# Altium schematic sheet Measurement.SchDoc(Measurement)
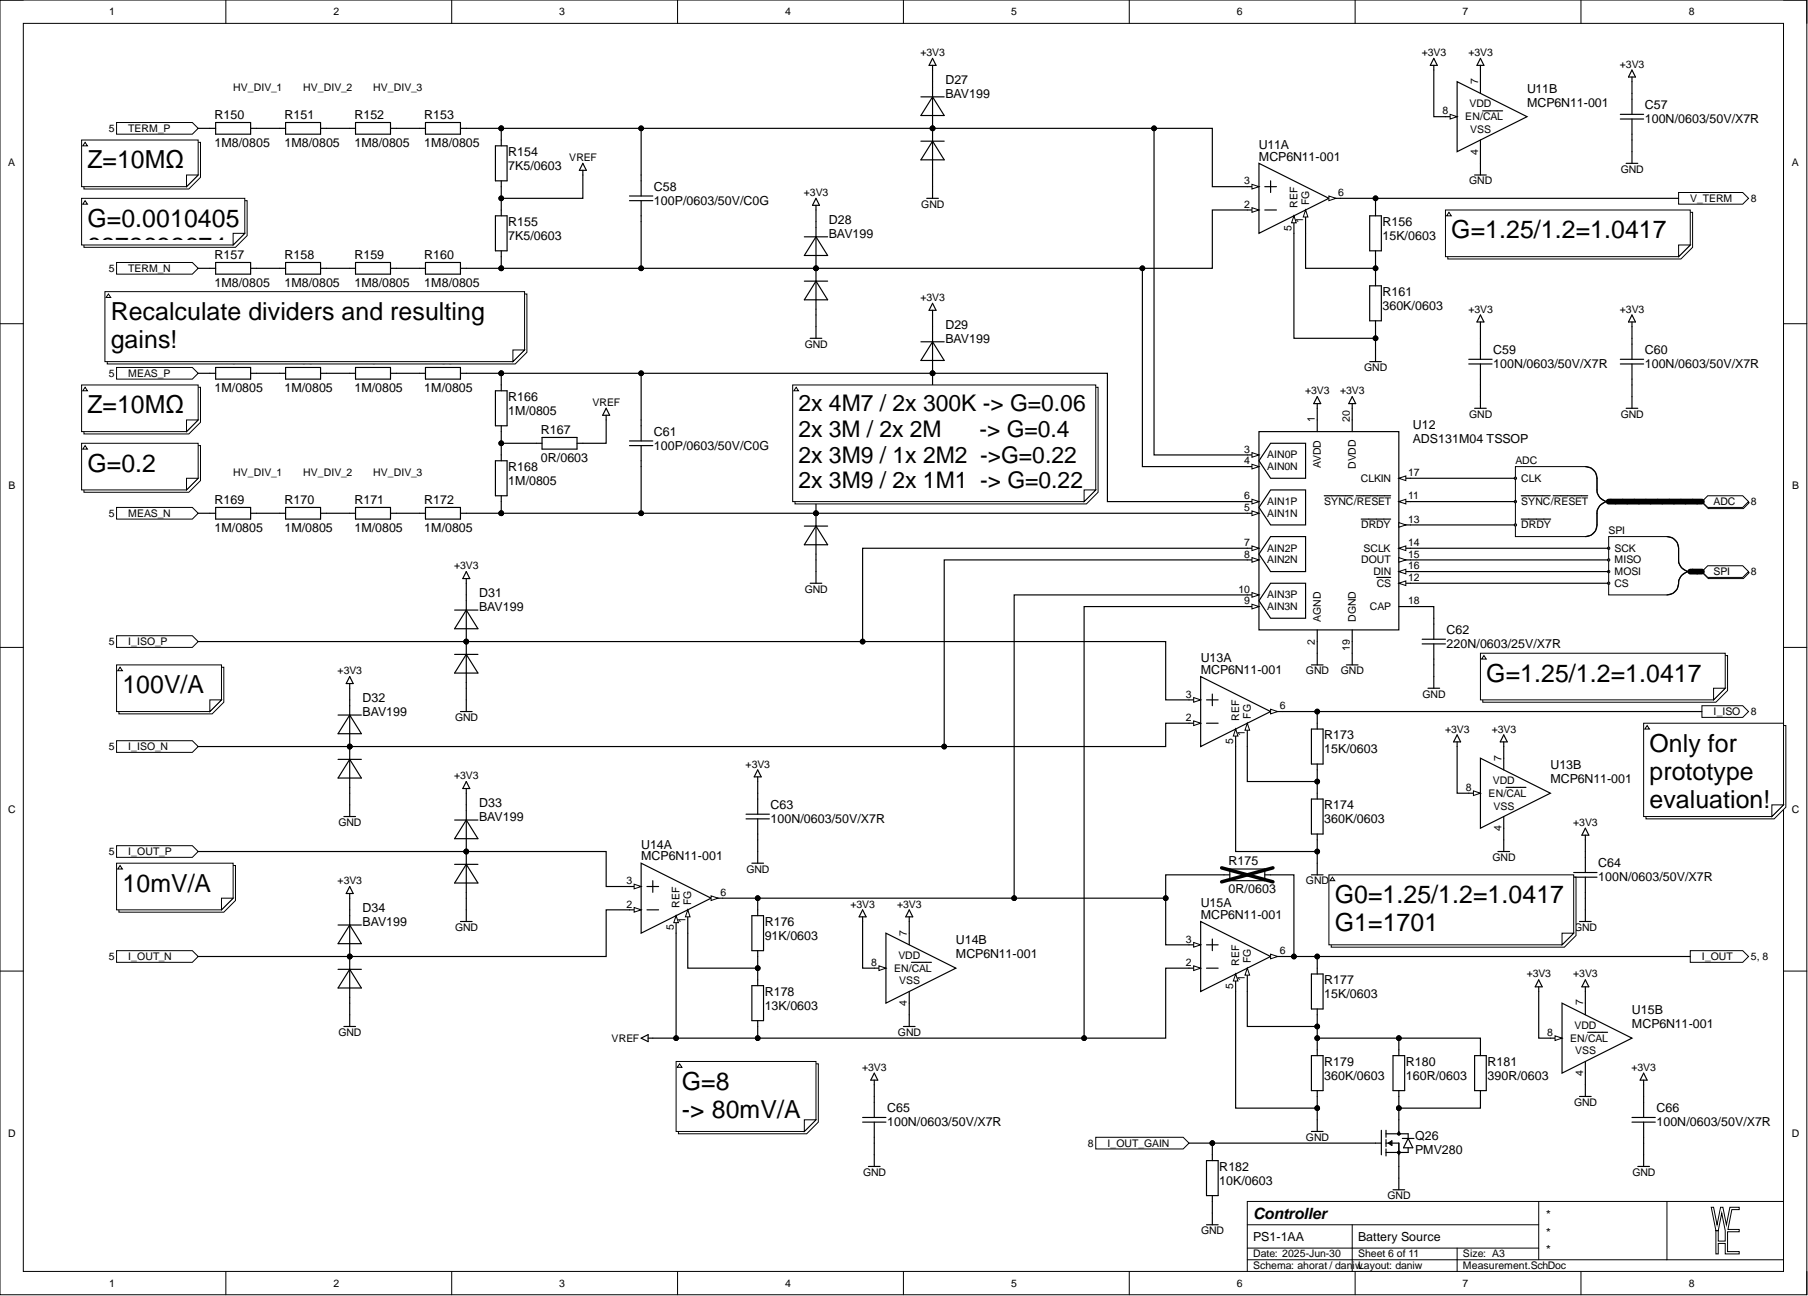
[diagram p0: Measurement.SchDoc]

## Parts
C57  100N/0603/50V/X7R  [CAP0603_X7R]
C58  100P/0603/50V/C0G  [CAP0603_C0G]
C59  100N/0603/50V/X7R  [CAP0603_X7R]
C60  100N/0603/50V/X7R  [CAP0603_X7R]
C61  100P/0603/50V/C0G  [CAP0603_C0G]
C62  220N/0603/25V/X7R  [CAP0603_X7R]
C63  100N/0603/50V/X7R  [CAP0603_X7R]
C64  100N/0603/50V/X7R  [CAP0603_X7R]
C65  100N/0603/50V/X7R  [CAP0603_X7R]
C66  100N/0603/50V/X7R  [CAP0603_X7R]
D27  BAV199  [SOT2395P280X125-3-SE]
D28  BAV199  [SOT2395P280X125-3-SE]
D29  BAV199  [SOT2395P280X125-3-SE]
D30  BAV199  [SOT2395P280X125-3-SE]
D31  BAV199  [SOT2395P280X125-3-SE]
D32  BAV199  [SOT2395P280X125-3-SE]
D33  BAV199  [SOT2395P280X125-3-SE]
D34  BAV199  [SOT2395P280X125-3-SE]
Q26  PMV280  [SOT2395P280X125-3-SE]
R150  1M8/0805  [R0805-0.50-WW]
R151  1M8/0805  [R0805-0.50-WW]
R152  1M8/0805  [R0805-0.50-WW]
R153  1M8/0805  [R0805-0.50-WW]
R154  7K5/0603  [R0603-0.40-WW]
R155  7K5/0603  [R0603-0.40-WW]
R156  15K/0603  [R0603-0.40-WW]
R157  1M8/0805  [R0805-0.50-WW]
R158  1M8/0805  [R0805-0.50-WW]
R159  1M8/0805  [R0805-0.50-WW]
R160  1M8/0805  [R0805-0.50-WW]
R161  360K/0603  [R0603-0.40-WW]
R162  1M/0805  [R0805-0.50-WW]
R163  1M/0805  [R0805-0.50-WW]
R164  1M/0805  [R0805-0.50-WW]
R165  1M/0805  [R0805-0.50-WW]
R166  1M/0805  [R0805-0.50-WW]
R167  0R/0603  [R0603-0.40-WW]
R168  1M/0805  [R0805-0.50-WW]
R169  1M/0805  [R0805-0.50-WW]
R170  1M/0805  [R0805-0.50-WW]
R171  1M/0805  [R0805-0.50-WW]
R172  1M/0805  [R0805-0.50-WW]
R173  15K/0603  [R0603-0.40-WW]
R174  360K/0603  [R0603-0.40-WW]
R175  0R/0603  [R0603-0.40-WW]
R176  91K/0603  [R0603-0.40-WW]
R177  15K/0603  [R0603-0.40-WW]
R178  13K/0603  [R0603-0.40-WW]
R179  360K/0603  [R0603-0.40-WW]
R180  160R/0603  [R0603-0.40-WW]
R181  390R/0603  [R0603-0.40-WW]
R182  10K/0603  [R0603-0.40-WW]
U11  MCP6N11-001  [SOP127P600X155-8-SW]  (parts A,B)
U12  ADS131M04 TSSOP  [SOP065P640X120-20NW]
U13  MCP6N11-001  [SOP127P600X155-8-SW]  (parts A,B)
U14  MCP6N11-001  [SOP127P600X155-8-SW]  (parts A,B)
U15  MCP6N11-001  [SOP127P600X155-8-SW]  (parts A,B)

## Ports
ADC, ADC.CLK, ADC.~{DRDY}, ADC.~{SYNC}/~{RESET}, I_ISO, I_ISO_N, I_ISO_P, I_OUT, I_OUT_GAIN, I_OUT_N, I_OUT_P, MEAS_N, MEAS_P, SPI, SPI.CS, SPI.MISO, SPI.MOSI, SPI.SCK, TERM_N, TERM_P, V_TERM

## Nets
+3V3: C57.1 C59.1 C60.1 C63.1 C64.1 C65.1 C66.1 D27.2 D28.2 D29.2 D30.2 D31.2 D32.2 D33.2 D34.2 U11.7 U11.8(EN/CAL) U12.1(AVDD) U12.20(DVDD) U13.7 U13.8(EN/CAL) U14.7 U14.8(EN/CAL) U15.7 U15.8(EN/CAL)
GND: C57.2 C59.2 C60.2 C62.2 C63.2 C64.2 C65.2 C66.2 D27.1 D28.1 D29.1 D30.1 D31.1 D32.1 D33.1 D34.1 Q26.2 R161.2 R174.2 R179.2 R182.2 U11.4 U11.5(REF) U12.2(AGND) U12.19(DGND) U13.4 U13.5(REF) U14.4 U15.4 U15.5(REF)
VREF: R154.2 R155.1 R167.2 R178.2 U12.9(AIN3N) U14.5(REF) U15.2
I_ISO: R173.1 U13.6 ; port I_ISO
I_ISO_N: D32.3 U12.8(AIN2N) U13.2 ; port I_ISO_N
I_ISO_P: D31.3 U12.7(AIN2P) U13.3 ; port I_ISO_P
I_OUT: R175.2 R177.1 U15.6 ; port I_OUT
I_OUT_GAIN: Q26.1 R182.1 ; port I_OUT_GAIN
I_OUT_N: D34.3 U14.2 ; port I_OUT_N
I_OUT_P: D33.3 U14.3 ; port I_OUT_P
MEAS_N: R169.1 ; port MEAS_N
MEAS_P: R162.1 ; port MEAS_P
TERM_N: R157.1 ; port TERM_N
TERM_P: R150.1 ; port TERM_P
V_TERM: R156.1 U11.6 ; port V_TERM
NetC58_1: C58.1 D27.3 R153.1 R154.1 U11.3 U12.3(AIN0P)
NetC58_2: C58.2 D28.3 R155.2 R160.2 U11.2 U12.4(AIN0N)
NetC61_1: C61.1 D29.3 R165.2 R166.1 U12.6(AIN1P)
NetC61_2: C61.2 D30.3 R168.2 R172.2 U12.5(AIN1N)
NetC62_1: C62.1 U12.18(CAP)
NetQ26_3: Q26.3 R180.2 R181.2
NetR150_2: R150.2 R151.2
NetR151_1: R151.1 R152.1
NetR152_2: R152.2 R153.2
NetR156_2: R156.2 R161.1 U11.1(FG)
NetR157_2: R157.2 R158.1
NetR158_2: R158.2 R159.1
NetR159_2: R159.2 R160.1
NetR162_2: R162.2 R163.1
NetR163_2: R163.2 R164.1
NetR164_2: R164.2 R165.1
NetR166_2: R166.2 R167.1 R168.1
NetR169_2: R169.2 R170.1
NetR170_2: R170.2 R171.1
NetR171_2: R171.2 R172.1
NetR173_2: R173.2 R174.1 U13.1(FG)
NetR175_1: R175.1 R176.1 U12.10(AIN3P) U14.6 U15.3
NetR176_2: R176.2 R178.1 U14.1(FG)
NetR177_2: R177.2 R179.1 R180.1 R181.1 U15.1(FG)
NetU12_11: U12.11(SYNC/RESET) ; port ADC
NetU12_12: U12.12(CS) ; port SPI
NetU12_13: U12.13(DRDY) ; port ADC
NetU12_14: U12.14(SCLK) ; port SPI
NetU12_15: U12.15(DOUT) ; port SPI
NetU12_16: U12.16(DIN) ; port SPI
NetU12_17: U12.17(CLKIN) ; port ADC

## Library data of the parts
C57 C59 C60 C63-C66 = 100N/0603/50V/X7R: CAP SM 0603 100nF 50V X7R; Manufacturer: GENERIC; Manufacturer 1: YAGEO; Manufacturer Part Number 1: CC0603KPX7R9BB104; Absolute Value: 1E-7; Database Table Name: C$; Eurocircuits GPN: GPC0603104; Component Kind: Standard; HelpURL: https://www.yageo.com/upload/media/product/app/datasheet/mlcc/upy-gphc_x7r_6_3v-to-250v.pdf; JLC Type: Basic; Manufacturer 2: YAGEO; Manufacturer Part Number 2: CC0603MRX7R9BB104; Manufacturer Part Number 3: CC0603KRX7R9BB104; Package: 0603; Part Number: 100N/0603/50V/X7R; Supplier 1: Digi-Key; Supplier 2: Digi-Key; Supplier 3: LCSC; Supplier Part Number 1: 311-3567-1-ND; Supplier Part Number 2: 311-4110-1-ND; Supplier Part Number 3: C14663; Value: 100nF; Library Name: daniw_altium_lib.DbLib
C58 C61 = 100P/0603/50V/C0G: CAP SM 0603 100pF 50V C0G; Manufacturer: GENERIC; Manufacturer 1: YAGEO; Manufacturer Part Number 1: CC0603JRNPO9BN101; Absolute Value: 1E-10; Database Table Name: C$; Eurocircuits GPN: GPC0603101-C0G; Component Kind: Standard; HelpURL: https://www.yageo.com/upload/media/product/productsearch/datasheet/mlcc/UPY-GP_NP0_16V-to-50V_18.pdf; JLC Type: Basic; Manufacturer 2: YAGEO; Manufacturer Part Number 2: CC0603KRNPO9BN101; Manufacturer Part Number 3: CL10C101JB8NNNC; Package: 0603; Part Number: 100P/0603/50V/C0G; Supplier 1: Digi-Key; Supplier 2: Digi-Key; Supplier 3: LCSC; Supplier Part Number 1: 311-1069-1-ND; Supplier Part Number 2: 311-3600-1-ND; Supplier Part Number 3: C14858; Value: 100pF; Library Name: daniw_altium_lib.DbLib
C62 = 220N/0603/25V/X7R: CAP SM 0603 220nF 25V X7R; Manufacturer: GENERIC; Absolute Value: 2.2E-7; Database Table Name: C$; Eurocircuits GPN: GPC0603; Component Kind: Standard; JLC Type: Basic; Manufacturer Part Number 3: CL10B224KA8NNNC; Package: 0603; Part Number: 220N/0603/25V/X7R; Supplier 3: LCSC; Supplier Part Number 3: C21120; Value: 220nF; Library Name: daniw_altium_lib.DbLib
D27-D34 = BAV199: Silicon Low Leakage Diode 85V; Manufacturer: Infineon Technologies; Manufacturer Part Number 1: BAV199E6433HTMA1; Database Table Name: D$; Absolute Value: 85V; Component Kind: Standard; HelpURL: https://www.infineon.com/dgdl/bav199series.pdf?folderId=db3a30431400ef6801141c748874044e&fileId=db3a30431400ef6801141cba733104e5; Manufacturer 1: Infineon Technologies; Manufacturer 3: LRC; Manufacturer Part Number 3: LBAV199LT1G; Package: SOT23; Part Number: BAV199; Supplier 1: Digikey; Supplier 3: LCSC; Supplier Part Number 1: BAV199E6433HTMA1CT-ND; Supplier Part Number 3: C12740; Value: BAV199; Library Name: daniw_altium_lib.DbLib
Q26 = PMV280ENEA: 100V, N-channel Trench MOSFET; Manufacturer: GENERIC; Manufacturer 1: Nexperia; Manufacturer Part Number 1: PMV280ENEAR; Database Table Name: T$; Component Kind: Standard; HelpURL: https://assets.nexperia.com/documents/data-sheet/PMV213SN.pdf; JLC Type: Extended; Manufacturer 3: Nexperia; Manufacturer Part Number 3: PMV280ENEA; Package: SOT23; Part Number: PMV280ENEA; Supplier 1: Digi-Key; Supplier 3: LCSC; Supplier Part Number 1: 1727-8341-1-ND; Supplier Part Number 3: C553089; Library Name: daniw_altium_lib.DbLib
R150-R153 R157-R160 = 1M8/0805: RES SM 0805 1M8; Manufacturer: GENERIC; Manufacturer 1: YAGEO; Manufacturer Part Number 1: RC0805FR-131M8L; Absolute Value: 1800000; Database Table Name: R$; Eurocircuits GPN: GPR08051M8; Component Kind: Standard; HelpURL: https://www.yageo.com/upload/media/product/app/datasheet/rchip/pyu-rc_group_51_rohs_l.pdf; Manufacturer 2: YAGEO; Manufacturer 3: FOJAN; Manufacturer Part Number 2: RC0805FR-071M8L; Manufacturer Part Number 3: FRC0805F1804TS; Package: 0805; Part Number: 1M8/0805; Supplier 1: Digi-Key; Supplier 2: Digi-Key; Supplier 3: LCSC; Supplier Part Number 1: 13-RC0805FR-131M8LCT-ND; Supplier Part Number 2: 311-1.80MCRCT-ND; Supplier Part Number 3: C2930213; Value: 1M8; Library Name: daniw_altium_lib.DbLib
R154 R155 = 7K5/0603: RES SM 0603 7K5; Manufacturer: GENERIC; Manufacturer 1: YAGEO; Manufacturer Part Number 1: RC0603FR-137K5L; Absolute Value: 7500; Database Table Name: R$; Eurocircuits GPN: GPR06037K5; Component Kind: Standard; HelpURL: https://www.yageo.com/upload/media/product/app/datasheet/rchip/pyu-rc_group_51_rohs_l.pdf; JLC Type: Basic; Manufacturer 2: YAGEO; Manufacturer 3: UNI-ROYAL(Uniroyal Elec); Manufacturer Part Number 2: RC0603FR-107K5L; Manufacturer Part Number 3: 0603WAF7501T5E; Package: 0603; Part Number: 7K5/0603; Supplier 1: Digi-Key; Supplier 2: Digi-Key; Supplier 3: LCSC; Supplier Part Number 1: 13-RC0603FR-137K5LCT-ND; Supplier Part Number 2: 13-RC0603FR-107K5LCT-ND; Supplier Part Number 3: C23234; Value: 7K5; Library Name: daniw_altium_lib.DbLib
R156 R173 R177 = 15K/0603: RES SM 0603 15K; Manufacturer: GENERIC; Manufacturer 1: YAGEO; Manufacturer Part Number 1: RC0603FR-1315KL; Absolute Value: 15000; Database Table Name: R$; Eurocircuits GPN: GPR060315K; Component Kind: Standard; HelpURL: https://www.yageo.com/upload/media/product/app/datasheet/rchip/pyu-rc_group_51_rohs_l.pdf; JLC Type: Basic; Manufacturer 2: YAGEO; Manufacturer 3: UNI-ROYAL(Uniroyal Elec); Manufacturer Part Number 2: RC0603FR-1015KL; Manufacturer Part Number 3: 0603WAF1502T5E; Package: 0603; Part Number: 15K/0603; Supplier 1: Digi-Key; Supplier 2: Digi-Key; Supplier 3: LCSC; Supplier Part Number 1: 13-RC0603FR-1315KLCT-ND; Supplier Part Number 2: 13-RC0603FR-1015KLCT-ND; Supplier Part Number 3: C22809; Value: 15K; Library Name: daniw_altium_lib.DbLib
R161 R174 R179 = 360K/0603: RES SM 0603 360K; Manufacturer: GENERIC; Manufacturer 1: YAGEO; Manufacturer Part Number 1: RC0603FR-07360KL; Absolute Value: 360000; Database Table Name: R$; Eurocircuits GPN: GPR0603360K; Component Kind: Standard; HelpURL: https://www.yageo.com/upload/media/product/app/datasheet/rchip/pyu-rc_group_51_rohs_l.pdf; JLC Type: Extended; Manufacturer 3: UNI-ROYAL(Uniroyal Elec); Manufacturer Part Number 3: 0603WAF3603T5E; Package: 0603; Part Number: 360K/0603; Supplier 1: Digi-Key; Supplier 3: LCSC; Supplier Part Number 1: 311-360KHRCT-ND; Supplier Part Number 3: C23146; Value: 360K; Library Name: daniw_altium_lib.DbLib
R162-R166 R168-R172 = 1M/0805: RES SM 0805 1M; Manufacturer: GENERIC; Manufacturer 1: YAGEO; Manufacturer Part Number 1: RC0805FR-131ML; Absolute Value: 1000000; Database Table Name: R$; Eurocircuits GPN: GPR08051M; Component Kind: Standard; HelpURL: https://www.yageo.com/upload/media/product/productsearch/datasheet/rchip/PYu-RC_Group_51_RoHS_L_12.pdf; JLC Type: Basic; Manufacturer 2: YAGEO; Manufacturer 3: UNI-ROYAL(Uniroyal Elec); Manufacturer Part Number 2: RC0805FR-101ML; Manufacturer Part Number 3: 0805W8F1004T5E; Package: 0805; Part Number: 1M/0805; Supplier 1: Digi-Key; Supplier 2: Digi-Key; Supplier 3: LCSC; Supplier Part Number 1: 13-RC0805FR-131MLCT-ND; Supplier Part Number 2: 13-RC0805FR-101MLCT-ND; Supplier Part Number 3: C17514; Value: 1M; Library Name: daniw_altium_lib.DbLib
R167 R175 = 0R/0603: RES SM 0603 0R; Manufacturer: GENERIC; Manufacturer 1: YAGEO; Manufacturer Part Number 1: RC0603FR-070RL; Absolute Value: 0; Database Table Name: R$; Eurocircuits GPN: GPR06030R; Component Kind: Standard; HelpURL: https://www.yageo.com/upload/media/product/app/datasheet/rchip/pyu-rc_group_51_rohs_l.pdf; JLC Type: Basic; Manufacturer 2: YAGEO; Manufacturer 3: UNI-ROYAL(Uniroyal Elec); Manufacturer Part Number 2: RC0603FR-130RL; Manufacturer Part Number 3: 0603WAF0000T5E; Package: 0603; Part Number: 0R/0603; Supplier 1: Digi-Key; Supplier 2: Digi-Key; Supplier 3: LCSC; Supplier Part Number 1: 311-0.0HRCT-ND; Supplier Part Number 2: 13-RC0603FR-130RLCT-ND; Supplier Part Number 3: C21189; Value: 0R; Library Name: daniw_altium_lib.DbLib
R176 = 91K/0603: RES SM 0603 91K; Manufacturer: GENERIC; Manufacturer 1: YAGEO; Manufacturer Part Number 1: RC0603FR-1391KL; Absolute Value: 91000; Database Table Name: R$; Eurocircuits GPN: GPR060391K; Component Kind: Standard; HelpURL: https://www.yageo.com/upload/media/product/productsearch/datasheet/rchip/PYu-RC_Group_51_RoHS_L_12.pdf; JLC Type: Extended; Manufacturer 2: YAGEO; Manufacturer 3: UNI-ROYAL(Uniroyal Elec); Manufacturer Part Number 2: RC0603FR-0791KL; Manufacturer Part Number 3: 0603WAF9102T5E; Package: 0603; Part Number: 91K/0603; Supplier 1: Digi-Key; Supplier 2: Digi-Key; Supplier 3: LCSC; Supplier Part Number 1: 13-RC0603FR-1391KLCT-ND; Supplier Part Number 2: 311-91.0KHRCT-ND; Supplier Part Number 3: C23265; Value: 91K; Library Name: daniw_altium_lib.DbLib
R178 = 13K/0603: RES SM 0603 13K; Manufacturer: GENERIC; Manufacturer 1: YAGEO; Manufacturer Part Number 1: RC0603FR-1313KL; Absolute Value: 13000; Database Table Name: R$; Eurocircuits GPN: GPR060313K; Component Kind: Standard; HelpURL: https://www.yageo.com/upload/media/product/productsearch/datasheet/rchip/PYu-RC_Group_51_RoHS_L_12.pdf; JLC Type: Basic; Manufacturer 2: YAGEO; Manufacturer 3: UNI-ROYAL(Uniroyal Elec); Manufacturer Part Number 2: RC0603FR-1013KL; Manufacturer Part Number 3: 0603WAF1302T5E; Package: 0603; Part Number: 13K/0603; Supplier 1: Digi-Key; Supplier 2: Digi-Key; Supplier 3: LCSC; Supplier Part Number 1: 13-RC0603FR-1313KLCT-ND; Supplier Part Number 2: 13-RC0603FR-1013KLCT-ND; Supplier Part Number 3: C22797; Value: 13K; Library Name: daniw_altium_lib.DbLib
R180 = 160R/0603: RES SM 0603 160R; Manufacturer: GENERIC; Manufacturer 1: YAGEO; Manufacturer Part Number 1: RC0603FR-07160RL; Absolute Value: 160; Database Table Name: R$; Eurocircuits GPN: GPR0603160R; Component Kind: Standard; HelpURL: https://www.yageo.com/upload/media/product/app/datasheet/rchip/pyu-rc_group_51_rohs_l.pdf; JLC Type: Extended; Manufacturer 3: UNI-ROYAL(Uniroyal Elec); Manufacturer Part Number 3: 0603WAF1600T5E; Package: 0603; Part Number: 160R/0603; Supplier 1: Digi-Key; Supplier 3: LCSC; Supplier Part Number 1: 311-160HRCT-ND; Supplier Part Number 3: C22814; Value: 160R; Library Name: daniw_altium_lib.DbLib
R181 = 390R/0603: RES SM 0603 390R; Manufacturer: GENERIC; Manufacturer 1: YAGEO; Manufacturer Part Number 1: RC0603FR-13390RL; Absolute Value: 390; Database Table Name: R$; Eurocircuits GPN: GPR0603390R; Component Kind: Standard; HelpURL: https://www.yageo.com/upload/media/product/productsearch/datasheet/rchip/PYu-RC_Group_51_RoHS_L_12.pdf; JLC Type: Basic; Manufacturer 2: YAGEO; Manufacturer 3: UNI-ROYAL(Uniroyal Elec); Manufacturer Part Number 2: RC0603FR-10390RL; Manufacturer Part Number 3: 0603WAF3900T5E; Package: 0603; Part Number: 390R/0603; Supplier 1: Digi-Key; Supplier 2: Digi-Key; Supplier 3: LCSC; Supplier Part Number 1: 13-RC0603FR-13390RLCT-ND; Supplier Part Number 2: 13-RC0603FR-10390RLCT-ND; Supplier Part Number 3: C23151; Value: 390R; Library Name: daniw_altium_lib.DbLib
R182 = 10K/0603: RES SM 0603 10K; Manufacturer: GENERIC; Manufacturer 1: YAGEO; Manufacturer Part Number 1: RC0603FR-1310KL; Absolute Value: 10000; Database Table Name: R$; Eurocircuits GPN: GPR060310K; Component Kind: Standard; HelpURL: https://www.yageo.com/upload/media/product/productsearch/datasheet/rchip/PYu-RC_Group_51_RoHS_L_12.pdf; JLC Type: Basic; Manufacturer 2: YAGEO; Manufacturer 3: UNI-ROYAL(Uniroyal Elec); Manufacturer Part Number 2: RC0603FR-1010KL; Manufacturer Part Number 3: 0603WAF1002T5E; Package: 0603; Part Number: 10K/0603; Supplier 1: Digi-Key; Supplier 2: Digi-Key; Supplier 3: LCSC; Supplier Part Number 1: 13-RC0603FR-1310KLCT-ND; Supplier Part Number 2: 13-RC0603FR-1010KLCT-ND; Supplier Part Number 3: C25804; Value: 10K; Library Name: daniw_altium_lib.DbLib
U11 U13-U15 = MCP6N11-001 SO-8: MCP6N11-001 500 kHz, 800 μA Instrumentation Amplifier SO-8; Manufacturer: Microchip Technology; Manufacturer Part Number 1: MCP6N11-001E/SN; Database Table Name: Integrated$; Component Kind: Standard; HelpURL: https://ww1.microchip.com/downloads/en/DeviceDoc/25073A.pdf; Manufacturer 1: Microchip Technology; Manufacturer 2: Microchip Technology; Manufacturer 3: Microchip Tech; Manufacturer Part Number 2: MCP6N11T-001E/SN; Manufacturer Part Number 3: MCP6N11T-001E/SN; Package: SO-8; Part Number: MCP6N11-001 SO-8; Supplier 1: Digi-Key; Supplier 2: Digi-Key; Supplier 3: LCSC; Supplier Part Number 1: MCP6N11-001E/SN-ND; Supplier Part Number 2: MCP6N11T-001E/SN-ND; Supplier Part Number 3: C623787; Library Name: daniw_altium_lib.DbLib
U12 = ADS131M04 TSSOP: ADS131M04 4-Channel, Simultaneously-Sampling, 24-Bit, Delta-Sigma ADC; Manufacturer: Texas Instruments; Manufacturer Part Number 1: ADS131M04IPWR; Database Table Name: Integrated$; Component Kind: Standard; HelpURL: https://www.ti.com/lit/ds/symlink/ads131m04.pdf; Manufacturer 1: Texas Instruments; Manufacturer 2: Texas Instruments; Manufacturer 3: Texas Instruments; Manufacturer Part Number 2: ADS131M04IPWT; Manufacturer Part Number 3: ADS131M04IPWR; Package: TSSOP-20; Part Number: ADS131M04 TSSOP; Supplier 1: Digi-Key; Supplier 2: Digi-Key; Supplier 3: LCSC; Supplier Part Number 1: 296-ADS131M04IPWRCT-ND; Supplier Part Number 2: 296-ADS131M04IPWTCT-ND; Supplier Part Number 3: C2862562; Library Name: daniw_altium_lib.DbLib
